# Altium schematic sheet TE0720_JB2.SchDoc(TE0720_JB2)
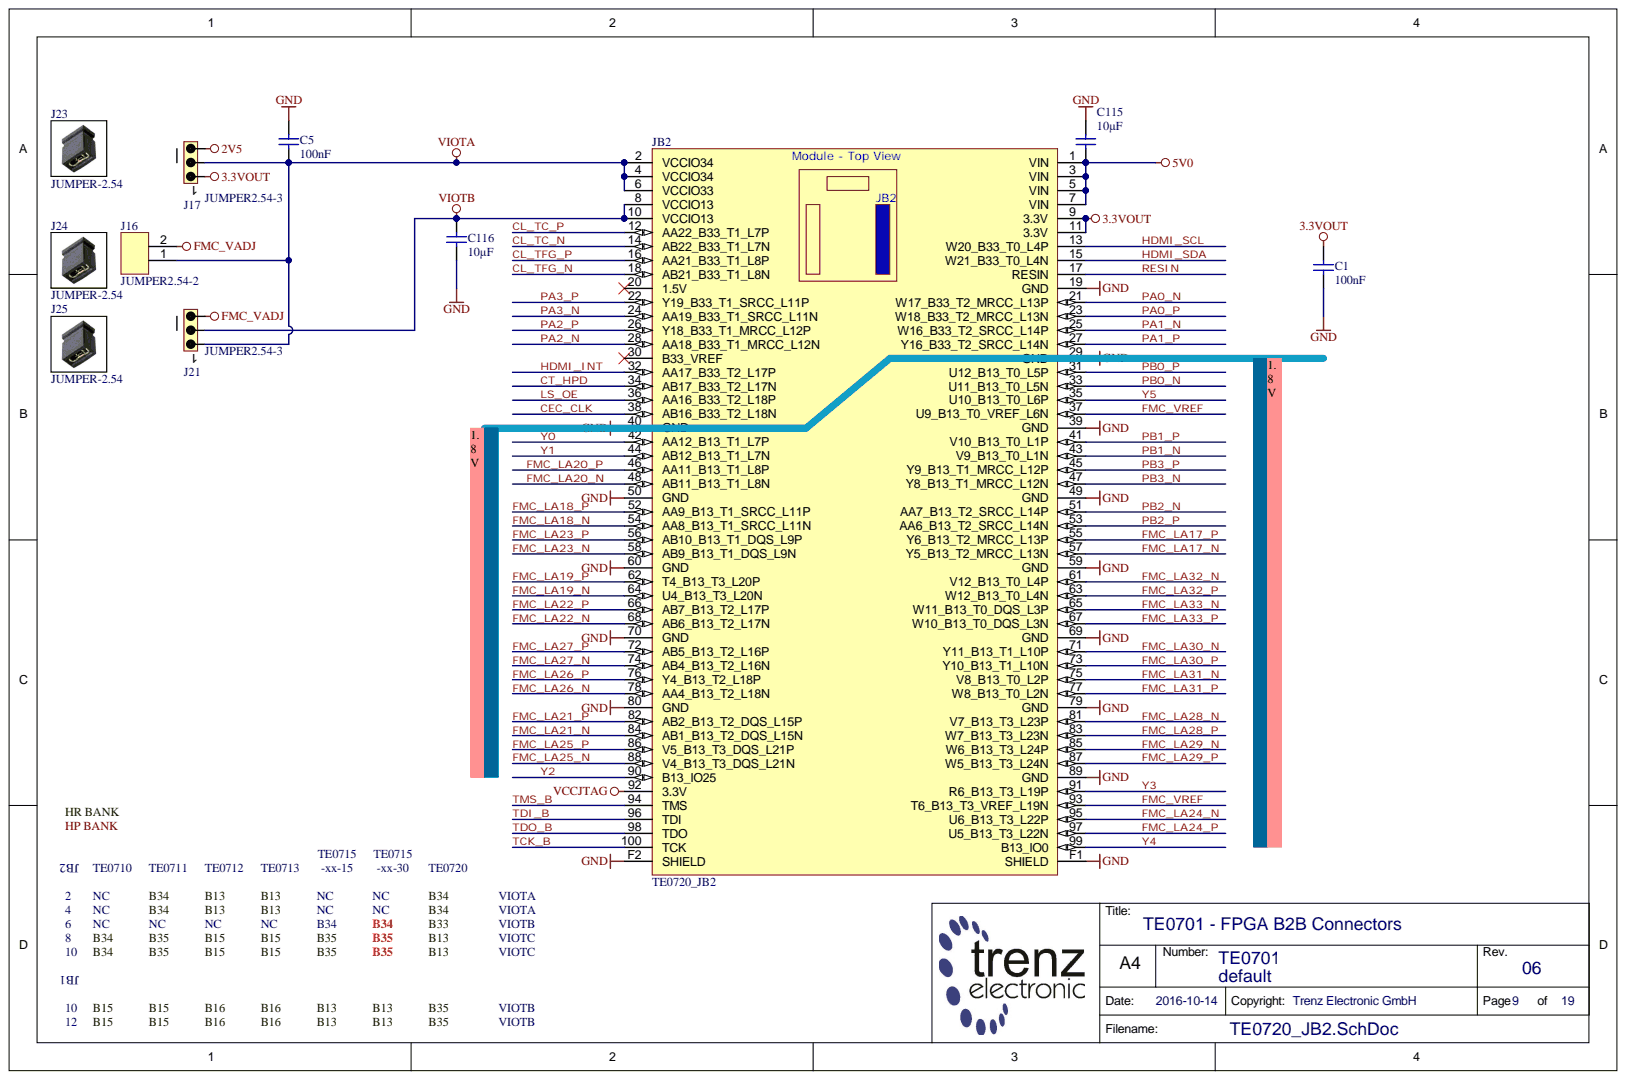
[diagram p0: TE0720_JB2.SchDoc]

## Parts
C1  100nF  [CAPC1005X55N]
C5  100nF  [CAPC1005X55N]
C115  10µF  [CAPC2012X135N]
C116  10µF  [CAPC2012X135N]
J16  JUMPER2.54-2  [JUMPER2_54-2]
J17  JUMPER2.54-3  [JUMPER2_54-3]
J21  JUMPER2.54-3  [JUMPER2_54-3]
J23  JUMPER-2.54  (mechanical)
J24  JUMPER-2.54  (mechanical)
J25  JUMPER-2.54  (mechanical)
JB2  TE0720_JB2  [LSHM-150-XXX-X-DV-A-X]

## Nets
2V5: J17.3
3.3VOUT: C1.1 J17.1 JB2.9(3.3V) JB2.11(3.3V)
5V0: C115.2 JB2.1(VIN) JB2.3(VIN) JB2.5(VIN) JB2.7(VIN)
FMC_VADJ: J16.2 J21.3
GND: C1.2 C5.2 C115.1 C116.1 JB2.19(GND) JB2.29(GND) JB2.39(GND) JB2.40(GND) JB2.49(GND) JB2.50(GND) JB2.59(GND) JB2.60(GND) JB2.69(GND) JB2.70(GND) JB2.79(GND) JB2.80(GND) JB2.89(GND) JB2.F1(SHIELD) JB2.F2(SHIELD)
VCCJTAG: JB2.92(3.3V)
VIOTA: C5.1 J16.1 J17.2 J21.1 JB2.2(VCCIO34) JB2.4(VCCIO34) JB2.6(VCCIO33)
VIOTB: C116.2 J21.2 JB2.8(VCCIO13) JB2.10(VCCIO13)
CEC_CLK: JB2.38(AB16_B33_T2_L18N)
CL_TC_N: JB2.14(AB22_B33_T1_L7N)
CL_TC_P: JB2.12(AA22_B33_T1_L7P)
CL_TFG_N: JB2.18(AB21_B33_T1_L8N)
CL_TFG_P: JB2.16(AA21_B33_T1_L8P)
CT_HPD: JB2.34(AB17_B33_T2_L17N)
FMC_LA17_N: JB2.57(Y5_B13_T2_MRCC_L13N)
FMC_LA17_P: JB2.55(Y6_B13_T2_MRCC_L13P)
FMC_LA18_N: JB2.54(AA8_B13_T1_SRCC_L11N)
FMC_LA18_P: JB2.52(AA9_B13_T1_SRCC_L11P)
FMC_LA19_N: JB2.64(U4_B13_T3_L20N)
FMC_LA19_P: JB2.62(T4_B13_T3_L20P)
FMC_LA20_N: JB2.48(AB11_B13_T1_L8N)
FMC_LA20_P: JB2.46(AA11_B13_T1_L8P)
FMC_LA21_N: JB2.84(AB1_B13_T2_DQS_L15N)
FMC_LA21_P: JB2.82(AB2_B13_T2_DQS_L15P)
FMC_LA22_N: JB2.68(AB6_B13_T2_L17N)
FMC_LA22_P: JB2.66(AB7_B13_T2_L17P)
FMC_LA23_N: JB2.58(AB9_B13_T1_DQS_L9N)
FMC_LA23_P: JB2.56(AB10_B13_T1_DQS_L9P)
FMC_LA24_N: JB2.95(U6_B13_T3_L22P)
FMC_LA24_P: JB2.97(U5_B13_T3_L22N)
FMC_LA25_N: JB2.88(V4_B13_T3_DQS_L21N)
FMC_LA25_P: JB2.86(V5_B13_T3_DQS_L21P)
FMC_LA26_N: JB2.78(AA4_B13_T2_L18N)
FMC_LA26_P: JB2.76(Y4_B13_T2_L18P)
FMC_LA27_N: JB2.74(AB4_B13_T2_L16N)
FMC_LA27_P: JB2.72(AB5_B13_T2_L16P)
FMC_LA28_N: JB2.81(V7_B13_T3_L23P)
FMC_LA28_P: JB2.83(W7_B13_T3_L23N)
FMC_LA29_N: JB2.85(W6_B13_T3_L24P)
FMC_LA29_P: JB2.87(W5_B13_T3_L24N)
FMC_LA30_N: JB2.71(Y11_B13_T1_L10P)
FMC_LA30_P: JB2.73(Y10_B13_T1_L10N)
FMC_LA31_N: JB2.75(V8_B13_T0_L2P)
FMC_LA31_P: JB2.77(W8_B13_T0_L2N)
FMC_LA32_N: JB2.61(V12_B13_T0_L4P)
FMC_LA32_P: JB2.63(W12_B13_T0_L4N)
FMC_LA33_N: JB2.65(W11_B13_T0_DQS_L3P)
FMC_LA33_P: JB2.67(W10_B13_T0_DQS_L3N)
FMC_VREF: JB2.37(U9_B13_T0_VREF_L6N) JB2.93(T6_B13_T3_VREF_L19N)
HDMI_INT: JB2.32(AA17_B33_T2_L17P)
HDMI_SCL: JB2.13(W20_B33_T0_L4P)
HDMI_SDA: JB2.15(W21_B33_T0_L4N)
LS_OE: JB2.36(AA16_B33_T2_L18P)
PA0_N: JB2.21(W17_B33_T2_MRCC_L13P)
PA0_P: JB2.23(W18_B33_T2_MRCC_L13N)
PA1_N: JB2.25(W16_B33_T2_SRCC_L14P)
PA1_P: JB2.27(Y16_B33_T2_SRCC_L14N)
PA2_N: JB2.28(AA18_B33_T1_MRCC_L12N)
PA2_P: JB2.26(Y18_B33_T1_MRCC_L12P)
PA3_N: JB2.24(AA19_B33_T1_SRCC_L11N)
PA3_P: JB2.22(Y19_B33_T1_SRCC_L11P)
PB0_N: JB2.33(U11_B13_T0_L5N)
PB0_P: JB2.31(U12_B13_T0_L5P)
PB1_N: JB2.43(V9_B13_T0_L1N)
PB1_P: JB2.41(V10_B13_T0_L1P)
PB2_N: JB2.51(AA7_B13_T2_SRCC_L14P)
PB2_P: JB2.53(AA6_B13_T2_SRCC_L14N)
PB3_N: JB2.47(Y8_B13_T1_MRCC_L12N)
PB3_P: JB2.45(Y9_B13_T1_MRCC_L12P)
RESIN: JB2.17(RESIN)
TCK_B: JB2.100(TCK)
TDI_B: JB2.96(TDI)
TDO_B: JB2.98(TDO)
TMS_B: JB2.94(TMS)
Y0: JB2.42(AA12_B13_T1_L7P)
Y1: JB2.44(AB12_B13_T1_L7N)
Y2: JB2.90(B13_IO25)
Y3: JB2.91(R6_B13_T3_L19P)
Y4: JB2.99(B13_IO0)
Y5: JB2.35(U10_B13_T0_L6P)

Not connected: JB2 20(1.5V) 30(B33_VREF)

## Library data of the parts
C1 C5 = 22472: KON 100nF 25V X5R SMD; Database Table Name: C_1005_0402; Mixed: SMT; Preferred: Yes; Value: 100n; AN: 22472; Case: 1005 (0402); Component Kind: Standard; Library Name: TE.DbLib; Technology: X7R; Voltage: 25V
C115 C116 = 24380: KON 10µF 16V X7R SMD 10%; Database Table Name: C_2012_0805; Mixed: SMT; Preferred: Yes; Value: 10u; AN: 24380; Case: 2012 (0805); Component Kind: Standard; Library Name: TE.DbLib; Technology: X7R; Tolerance: 10%; Voltage: 16V
J16 = 27212: Stiftleiste gerade 1-reihig, 2pol.; Database Table Name: CON_HEADER; Mixed: THT; Trenz-Article-Number: 22330; Trenz-Stock: Yes; AN: 27212; Component Kind: Standard; Library Name: TE.DbLib
J17 J21 = 22328: Stiftleiste gerade 1-reihig, 3pol.; Database Table Name: CON_HEADER; Mixed: THT; Trenz-Stock: Yes; AN: 22328; Component Kind: Standard; Library Name: TE.DbLib
J23-J25 = 20996: Jumper 2,54mm Raster, Schwarz offen; Database Table Name: CON_MATE; Mixed: MECH; AN: 20996; Library Name: TE.DbLib
JB2 = 25286: TE0720-JB2; Database Table Name: BLOCKS; Mixed: SMT; Trenz-Article-Number: 23838; Trenz-Stock: Yes; AN: 25286; Component Kind: Standard; Library Name: TE.DbLib
